# Altium schematic sheet SoM - PullUp PullDown.SchDoc("SoM - PullUp PullDown")
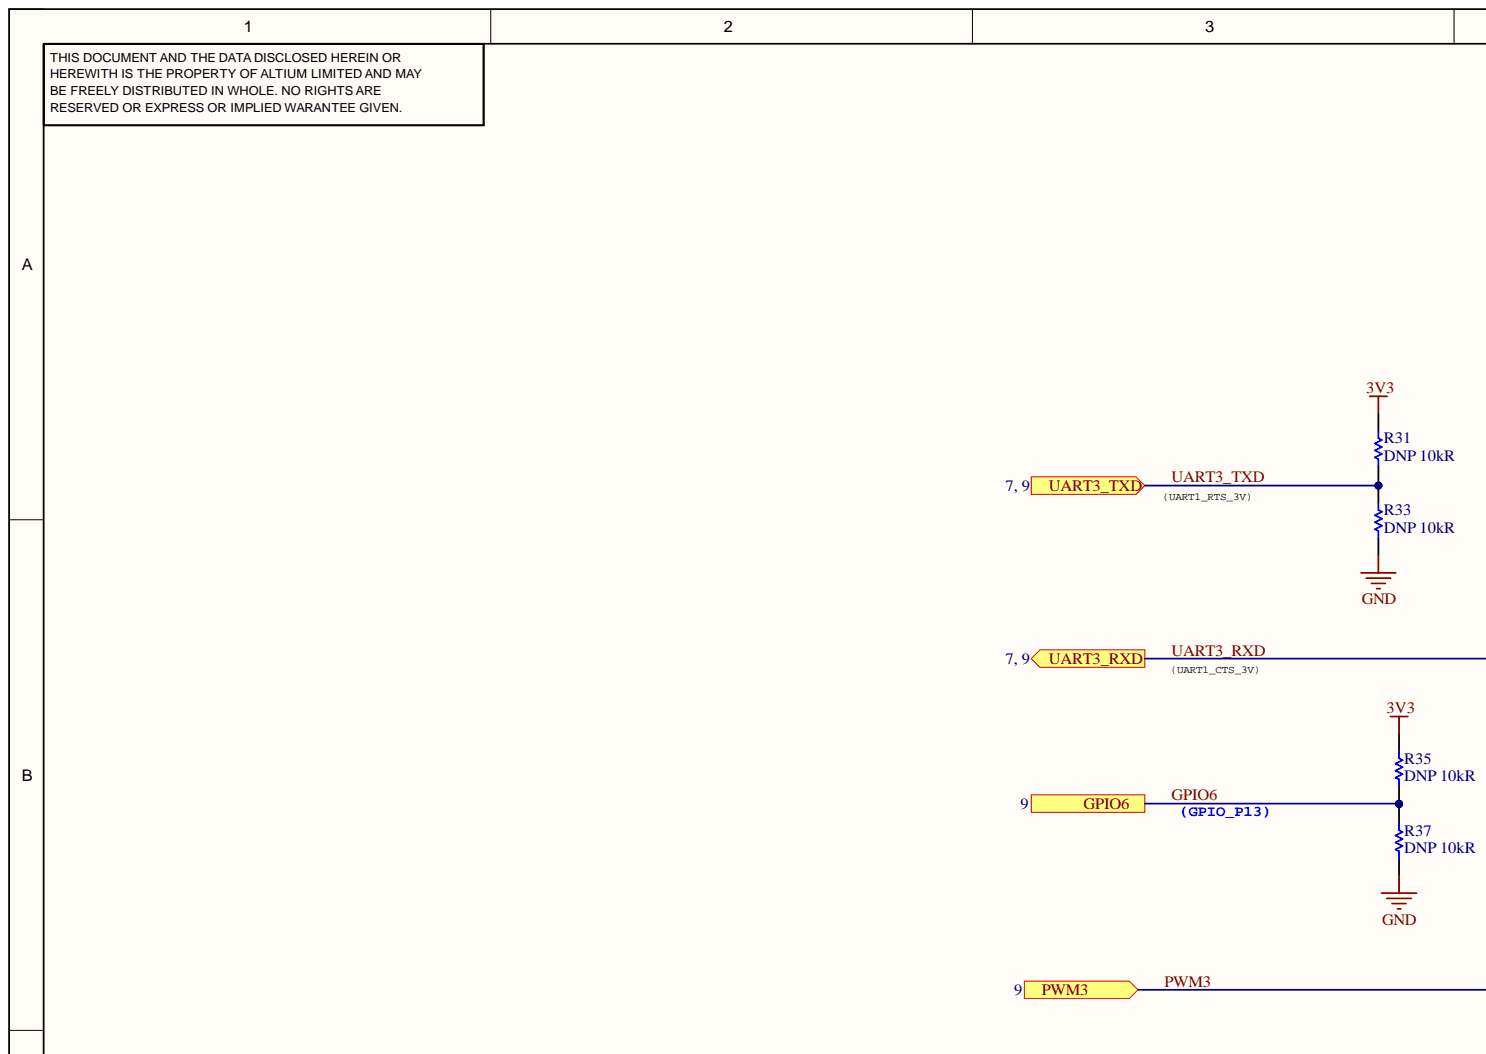
[diagram p0: SoM - PullUp PullDown.SchDoc, top left]
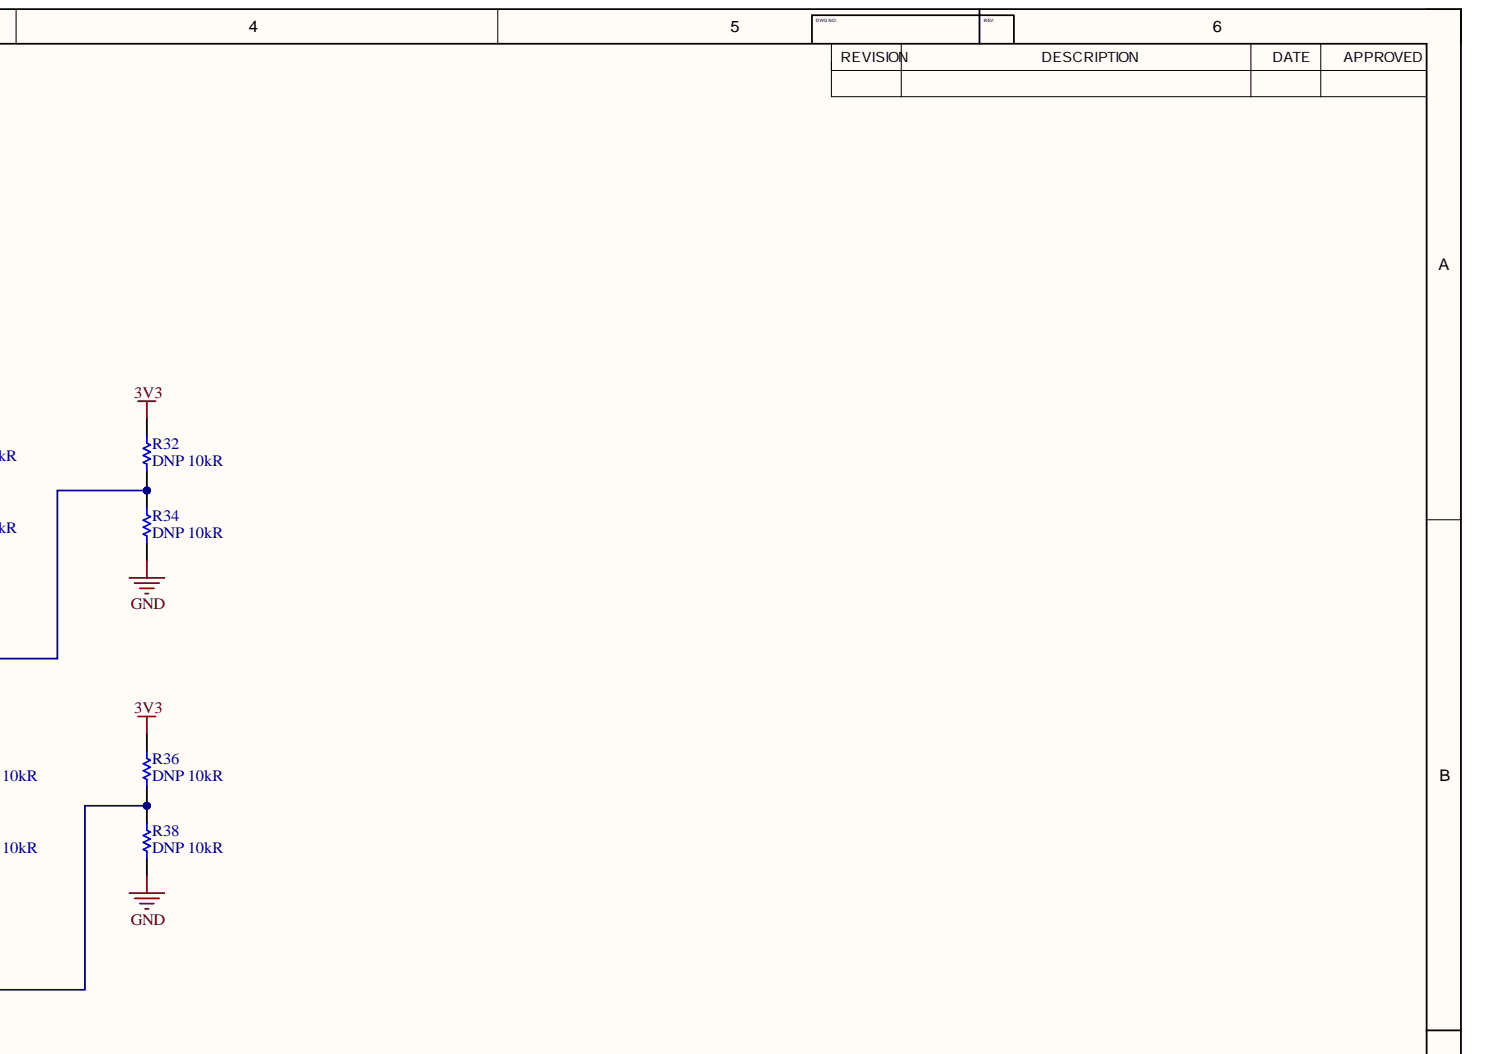
[diagram p1: SoM - PullUp PullDown.SchDoc, top right]
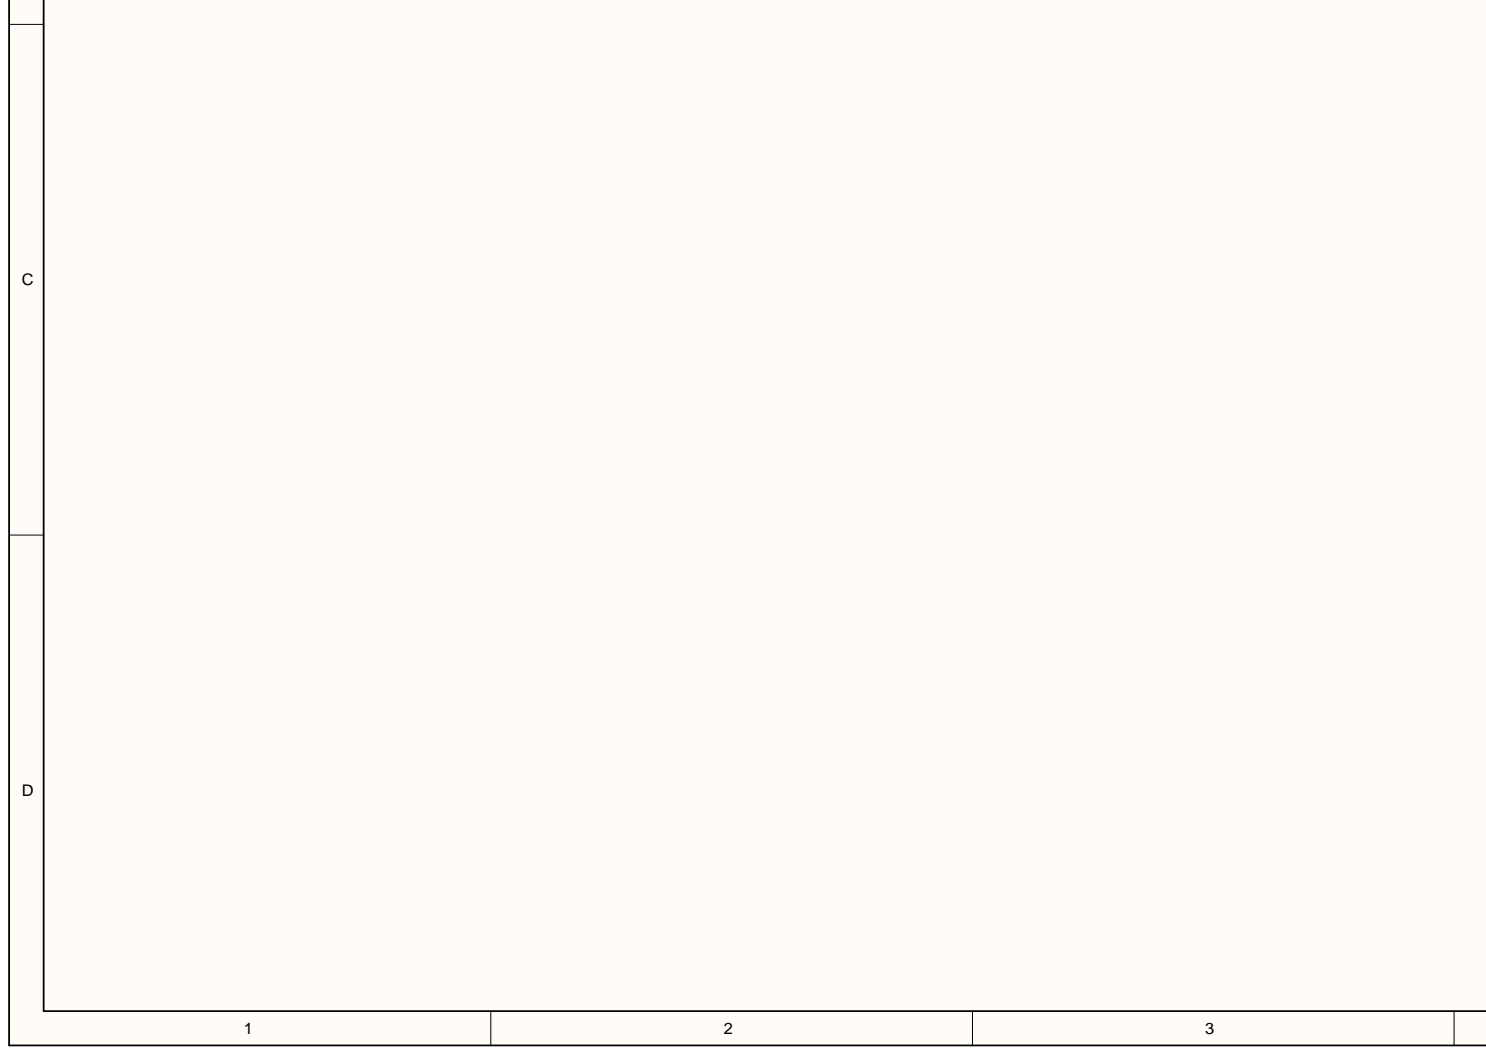
[diagram p2: SoM - PullUp PullDown.SchDoc, bottom left]
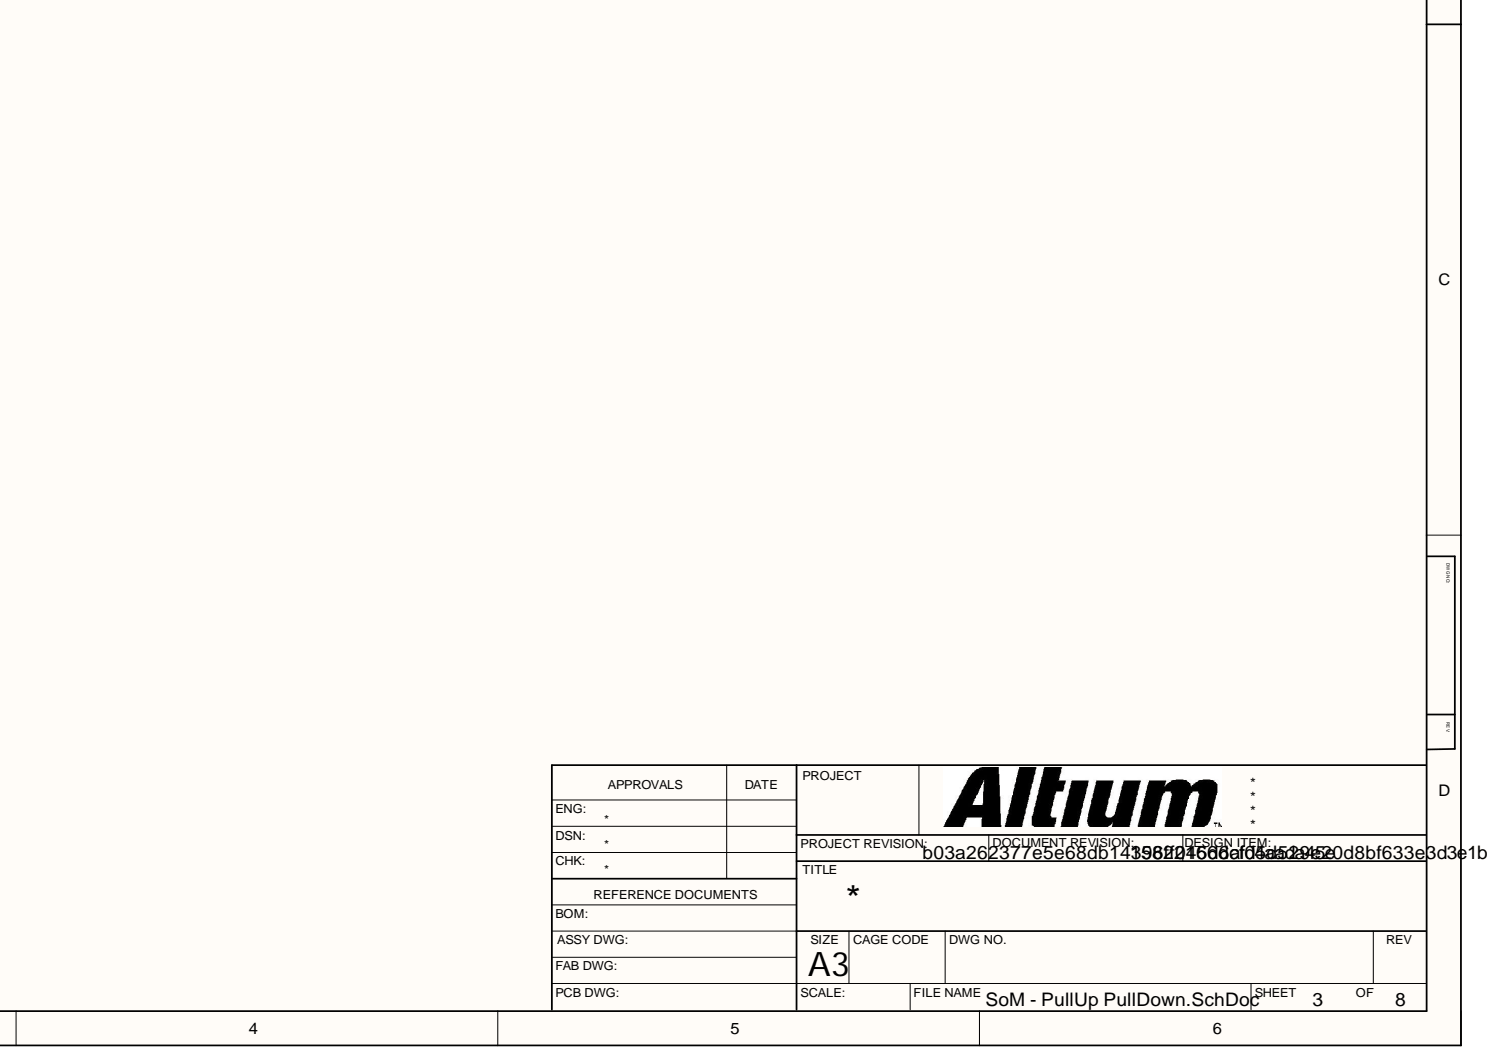
[diagram p3: SoM - PullUp PullDown.SchDoc, bottom right]

## Parts
R31  DNP 10kR  [RESC2013X60X35NL10T20]
R32  DNP 10kR  [RESC2013X60X35NL10T20]
R33  DNP 10kR  [RESC2013X60X35NL10T20]
R34  DNP 10kR  [RESC2013X60X35NL10T20]
R35  DNP 10kR  [RESC2013X60X35NL10T20]
R36  DNP 10kR  [RESC2013X60X35NL10T20]
R37  DNP 10kR  [RESC2013X60X35NL10T20]
R38  DNP 10kR  [RESC2013X60X35NL10T20]

## Ports
GPIO6, PWM3, UART3_RXD, UART3_TXD

## Nets
3V3: R31.1 R32.1 R35.1 R36.1
GND: R33.2 R34.2 R37.2 R38.2
GPIO6: R35.2 R37.1 ; port GPIO6
PWM3: R36.2 R38.1 ; port PWM3
UART3_RXD: R32.2 R34.1 ; port UART3_RXD
UART3_TXD: R31.2 R33.1 ; port UART3_TXD

## Library data of the parts
R31-R38 = CMP-1659-00013-4: RC0805FR-0710KL Chip Resistor, 10 KOhm, +/- 1%, 0.125 W, -55 to 155 degC, 0805 (2012 Metric), RoHS, Tape and Reel; Manufacturer: Yageo; Voltage Rating: 150 V; Component Kind: Standard; ComponentLink1Description: Manufacturer URL; ComponentLink1URL: http://www.yageo.com/; ComponentLink2Description: Datasheet; ComponentLink2URL: http://www.yageo.com/documents/recent/PYu-RC_Group_51_RoHS_L_04.pdf; DatasheetVersion: Rev. 4, 02/2016; Height: 0.5 mm; Length: 2 mm; Library Name: Altium Content Vault; Max Operating Temperature: 155 degC; Min Operating Temperature: -55 degC; Mount: Surface Mount; Mounting Technology: Surface Mount; Package Quantity: 5000; PackageDescription: 2-Pin Surface Mounted Device, Body 2 x 1.25 mm; PackageReference: 0805; Packaging: Tape and Reel; Pins: 2; REACH SVHC: No SVHC; RoHSCompliant: true
